# Altium schematic sheet P06_STM32MP1_PART_C_USB.SchDoc(P06_STM32MP1_PART_C_USB)
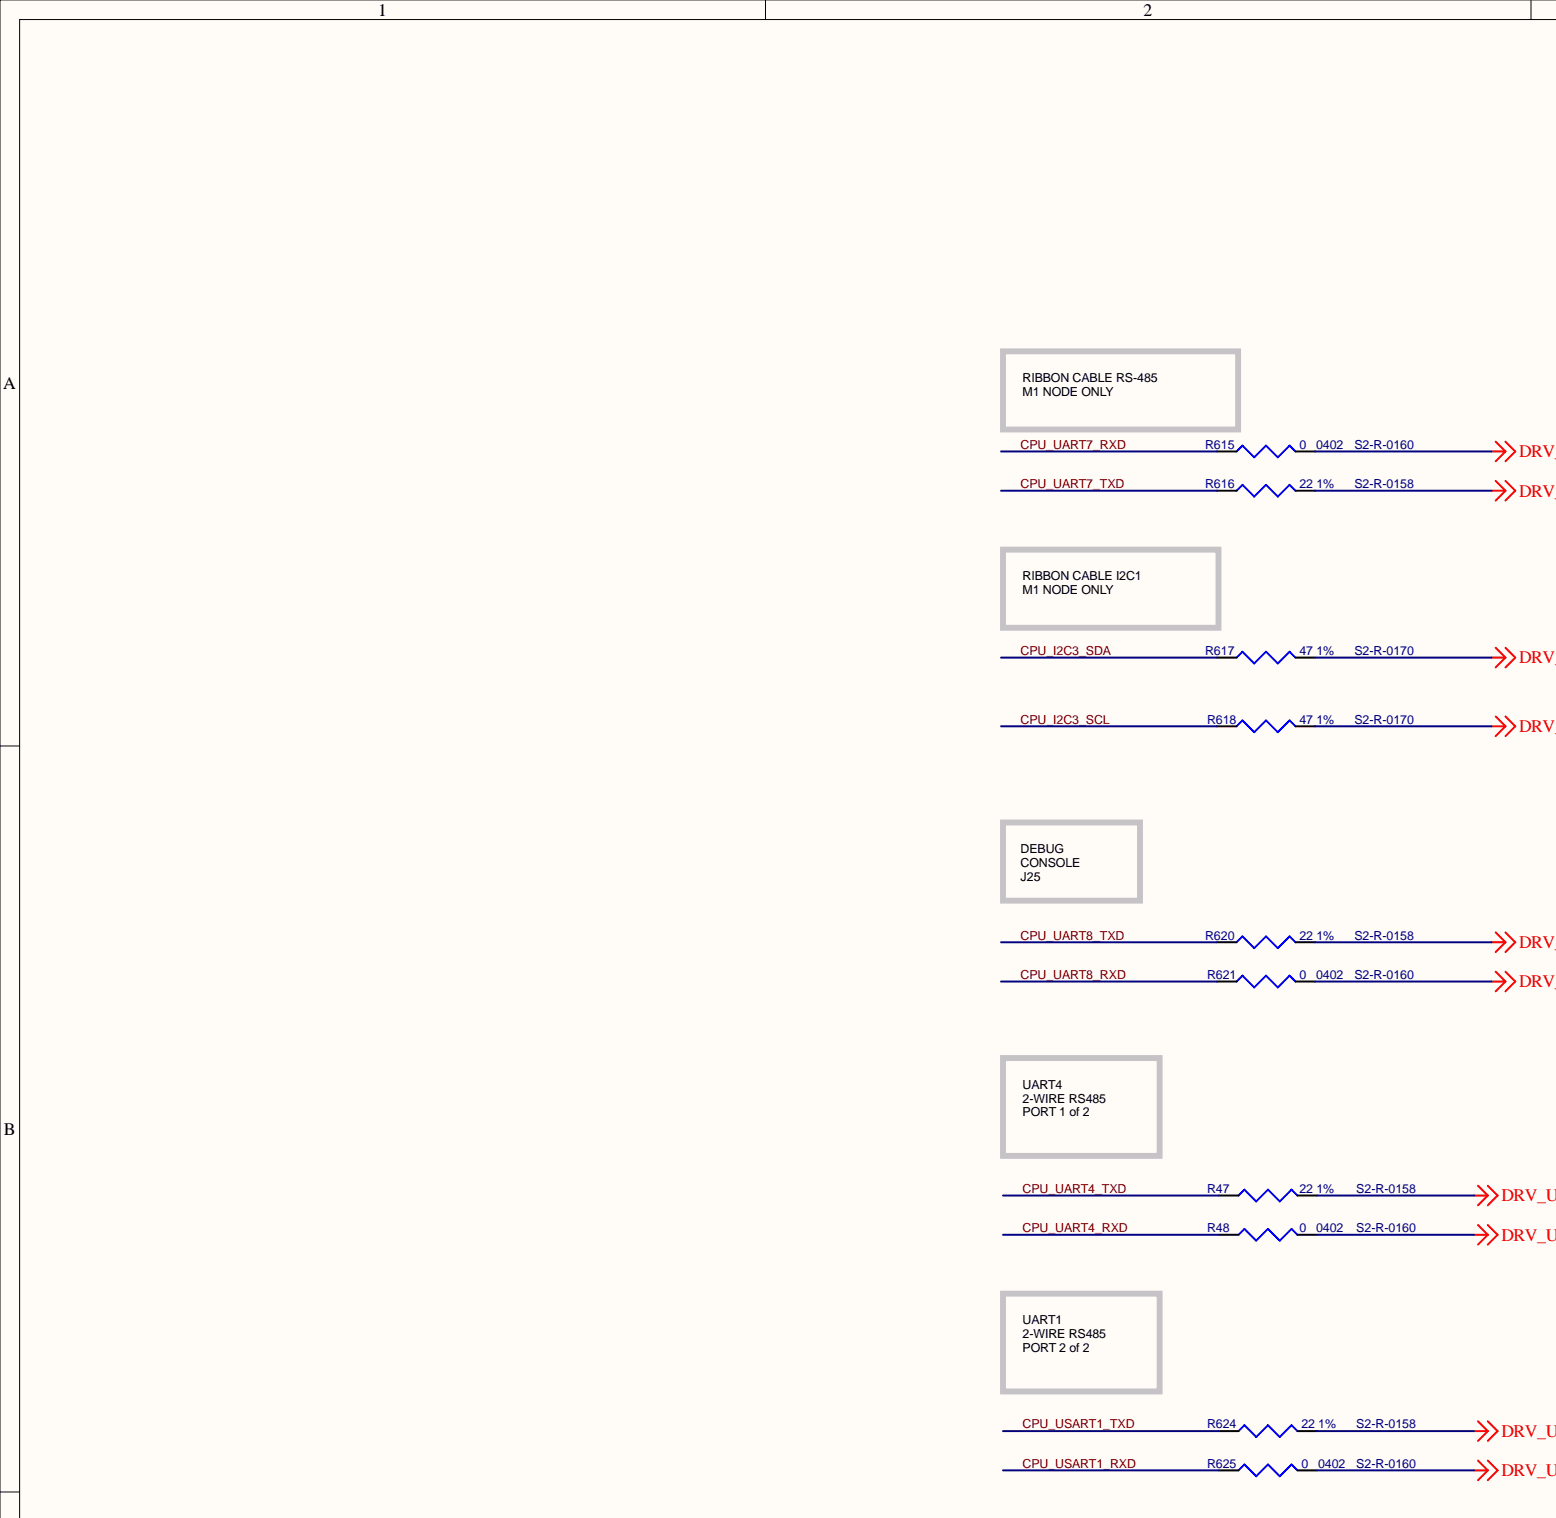
[diagram p0: P06_STM32MP1_PART_C_USB.SchDoc, top left]
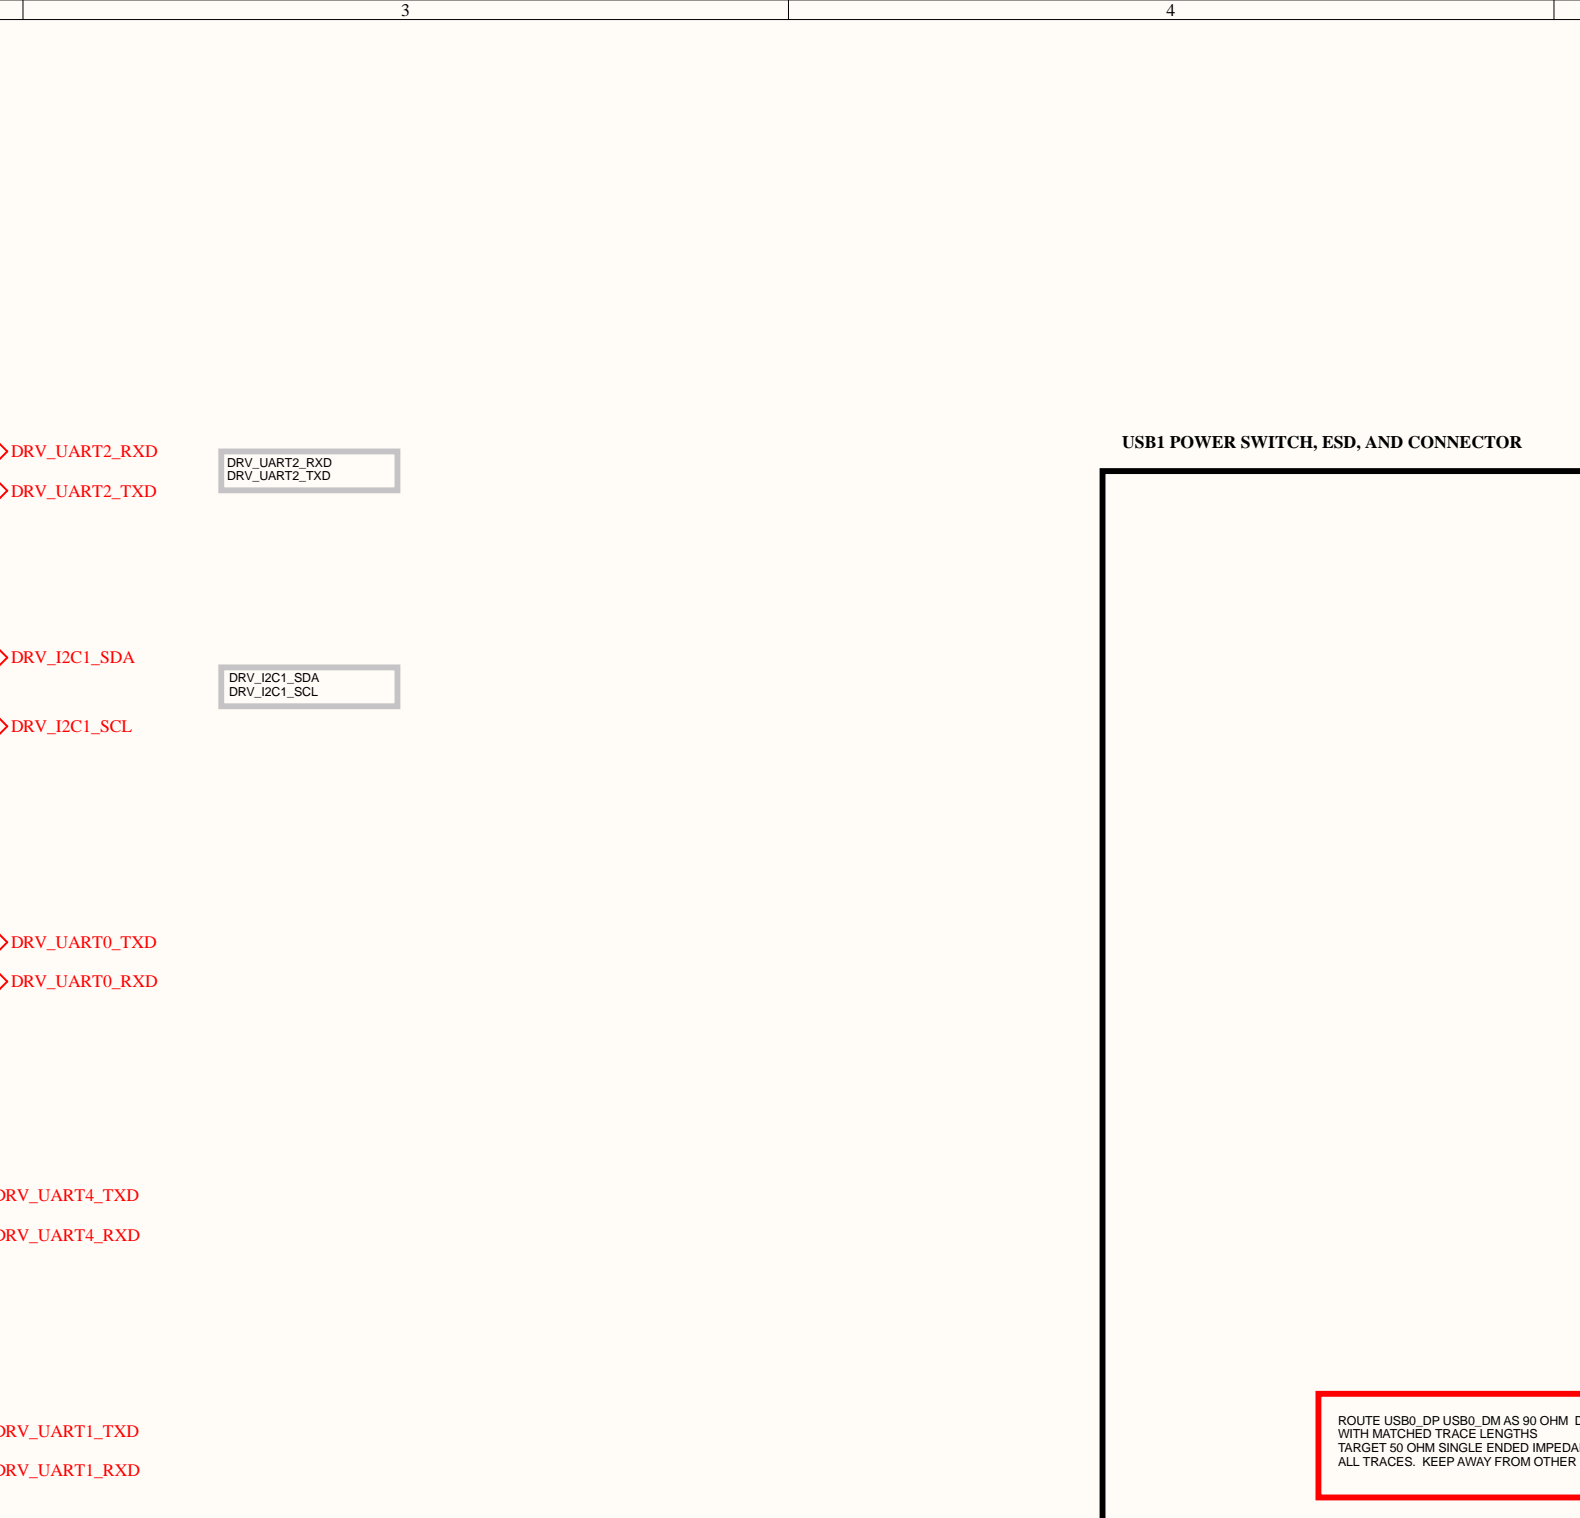
[diagram p1: P06_STM32MP1_PART_C_USB.SchDoc, top centre]
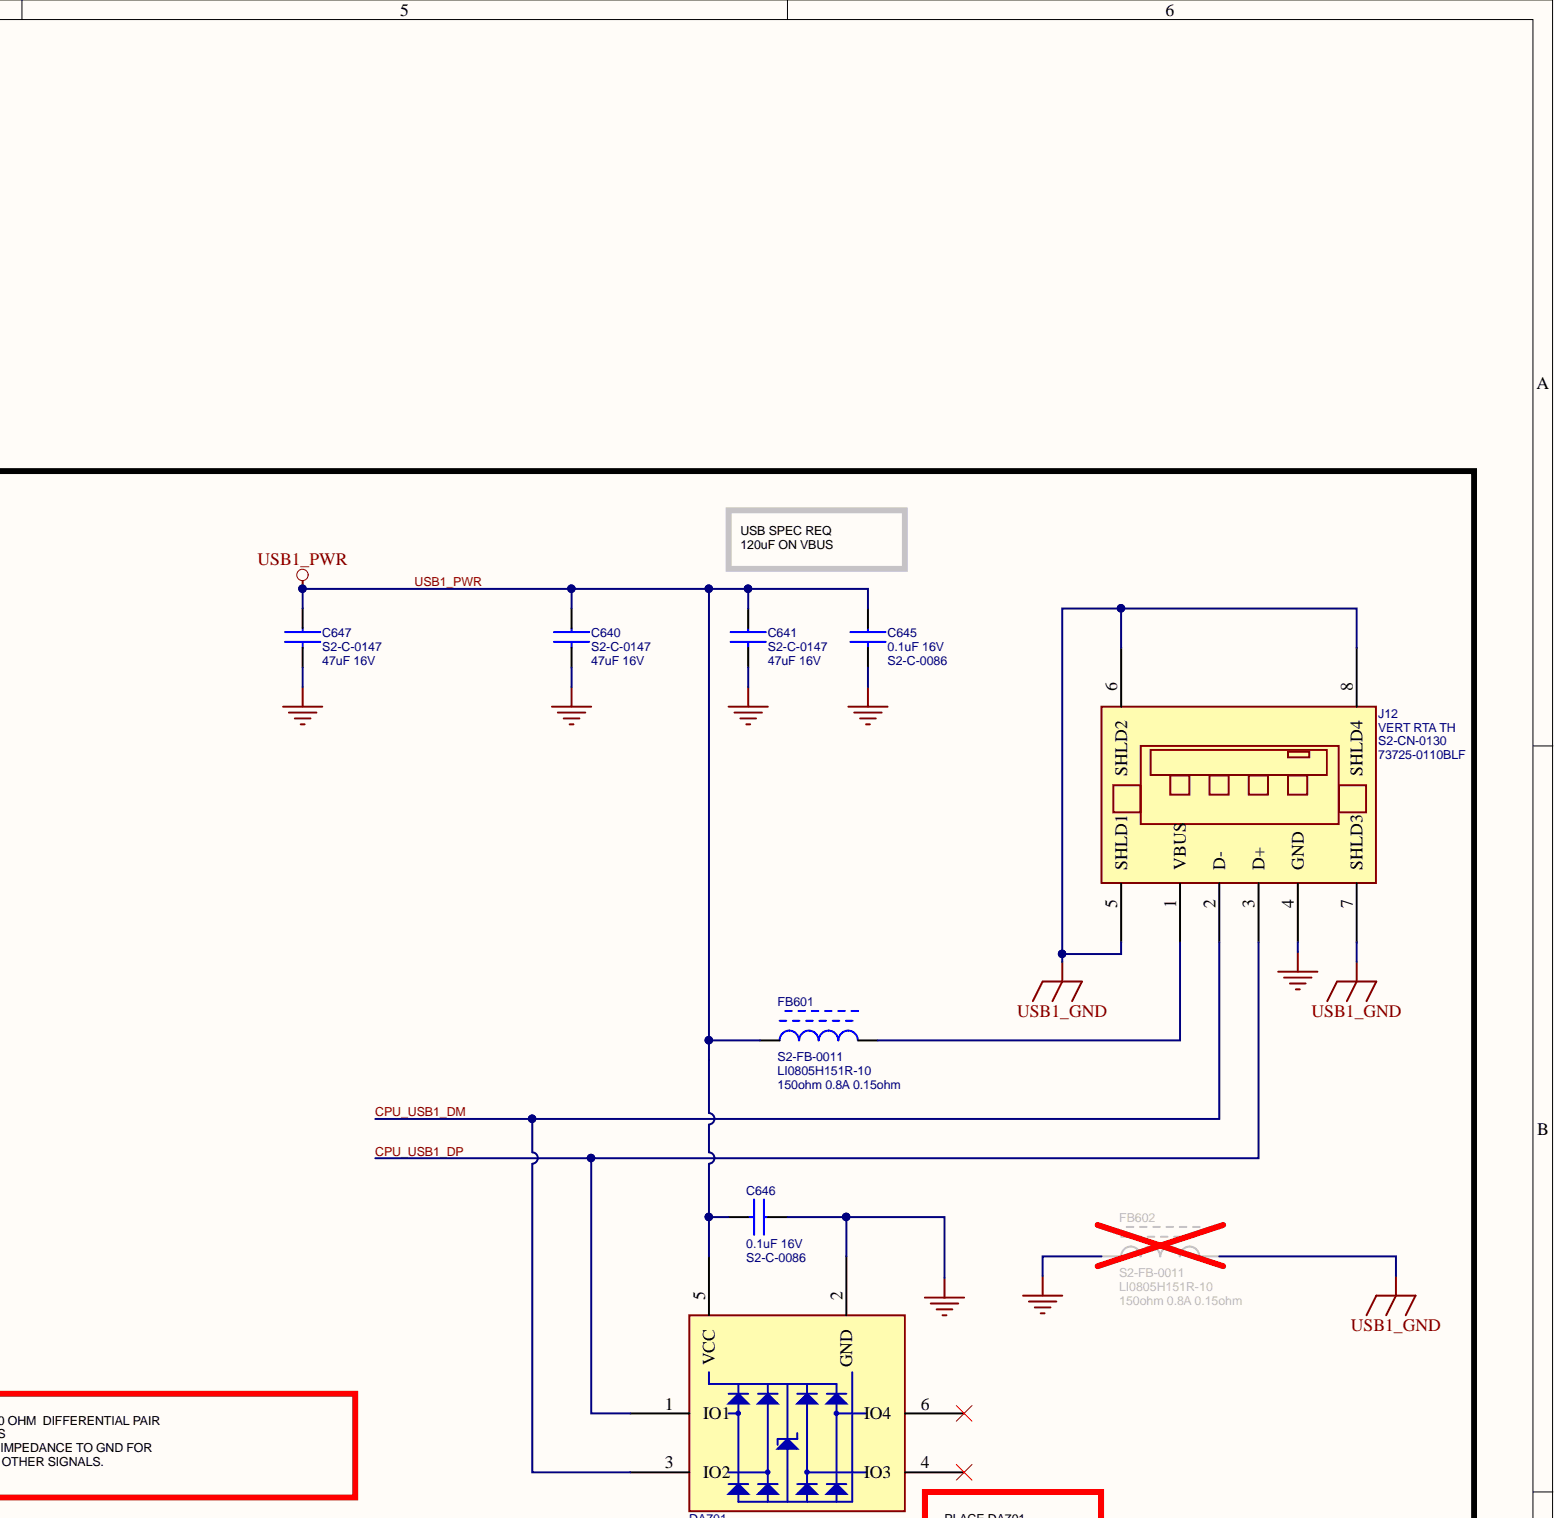
[diagram p2: P06_STM32MP1_PART_C_USB.SchDoc, top right]
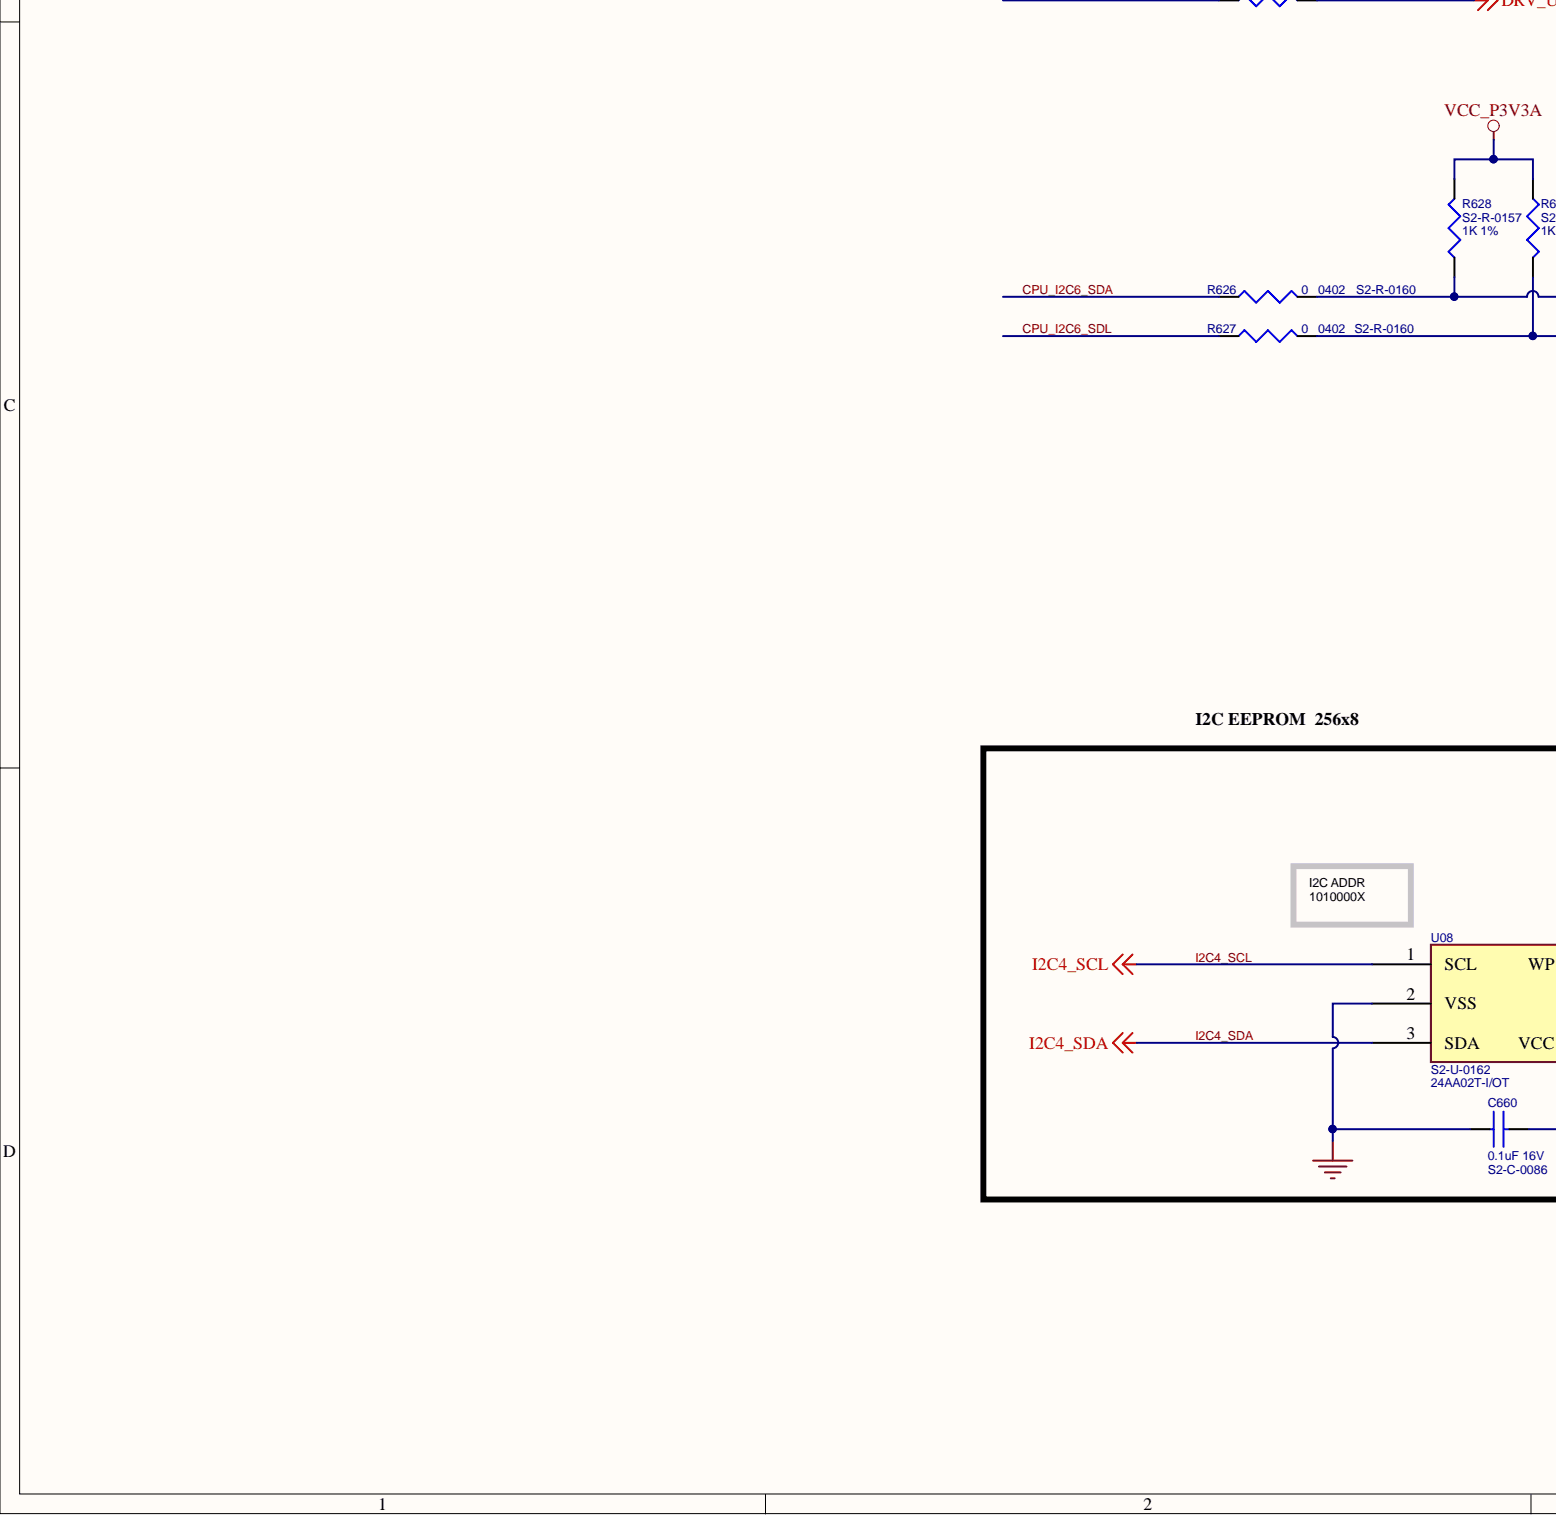
[diagram p3: P06_STM32MP1_PART_C_USB.SchDoc, bottom left]
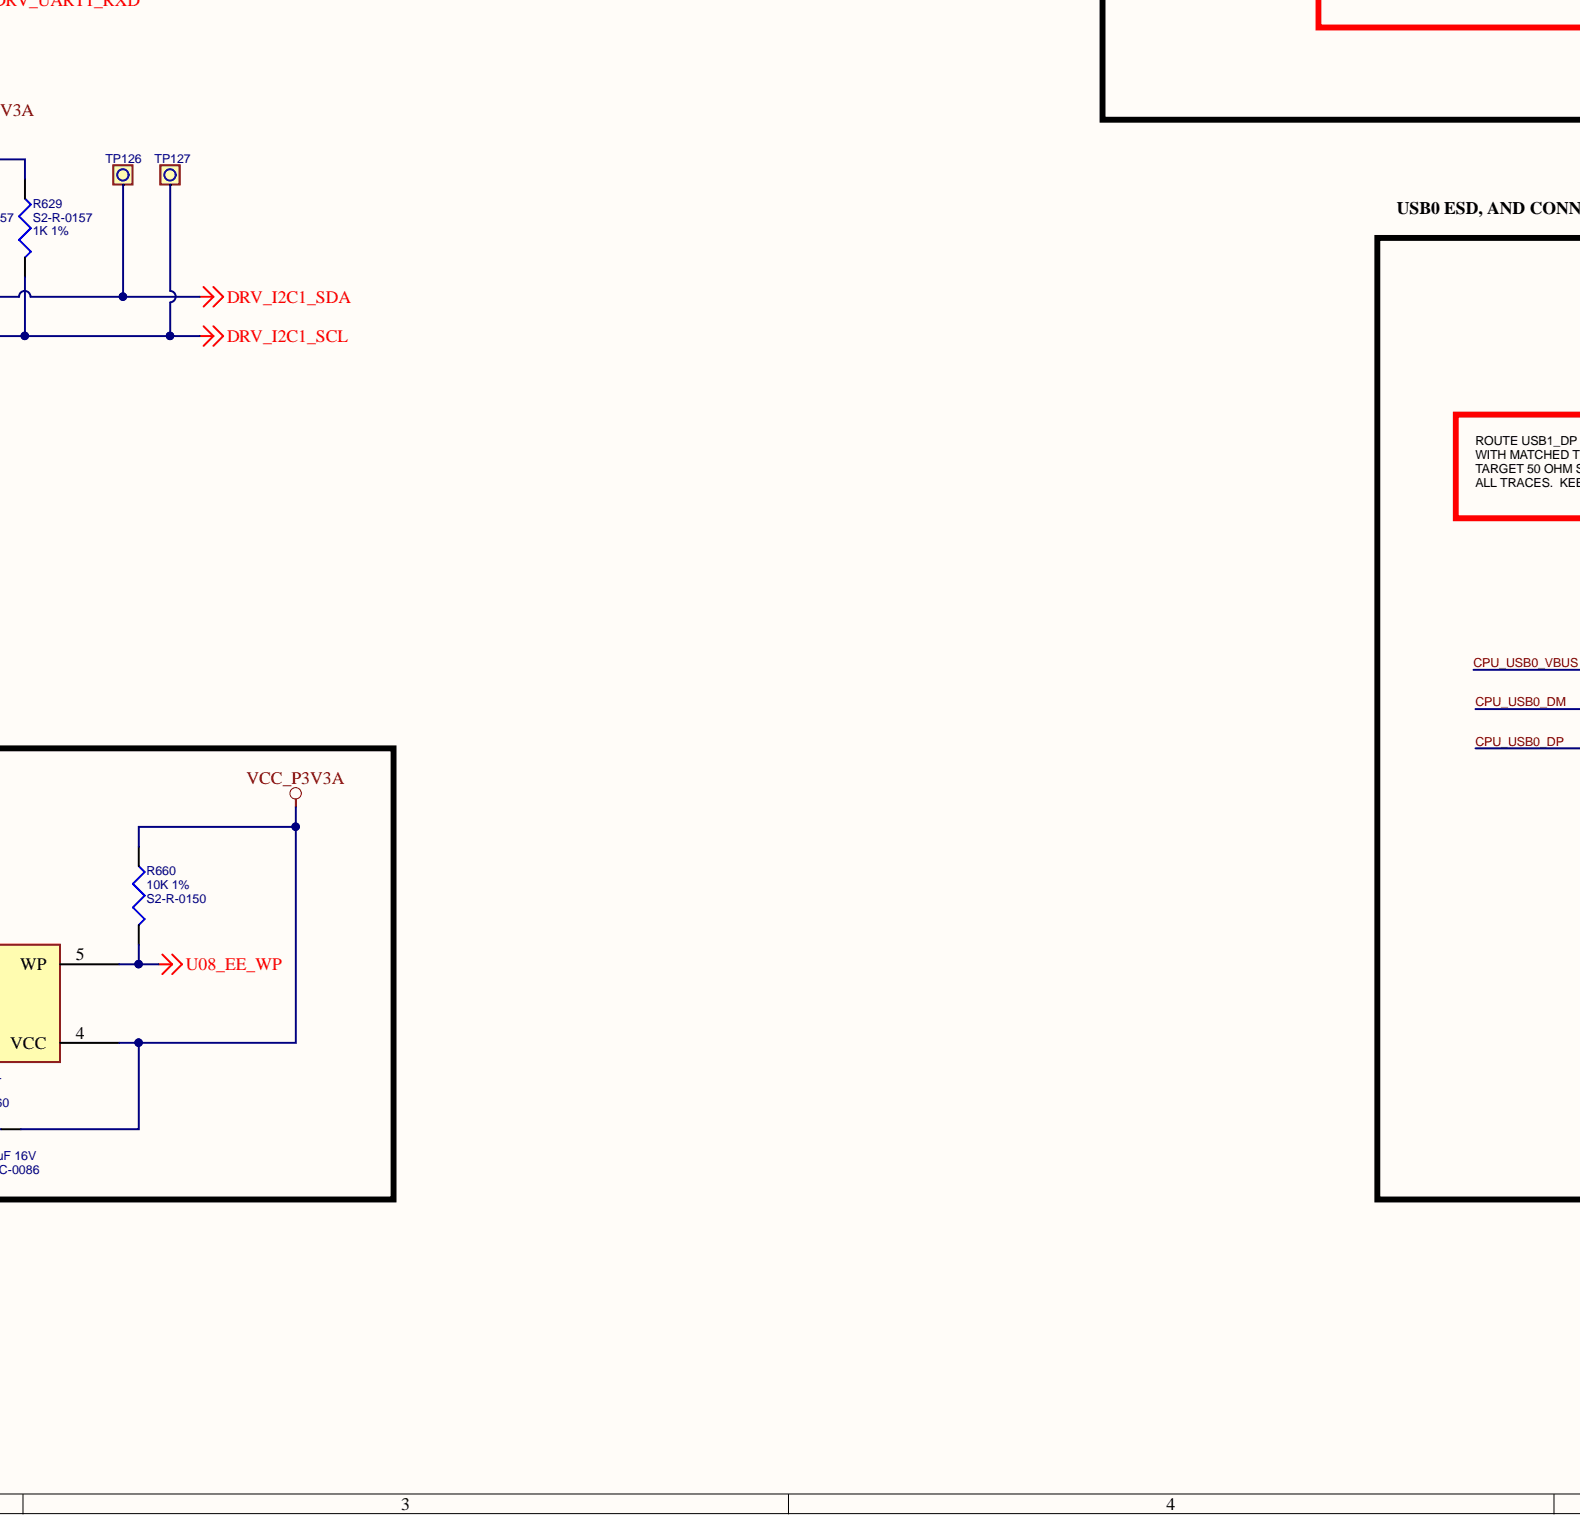
[diagram p4: P06_STM32MP1_PART_C_USB.SchDoc, bottom centre]
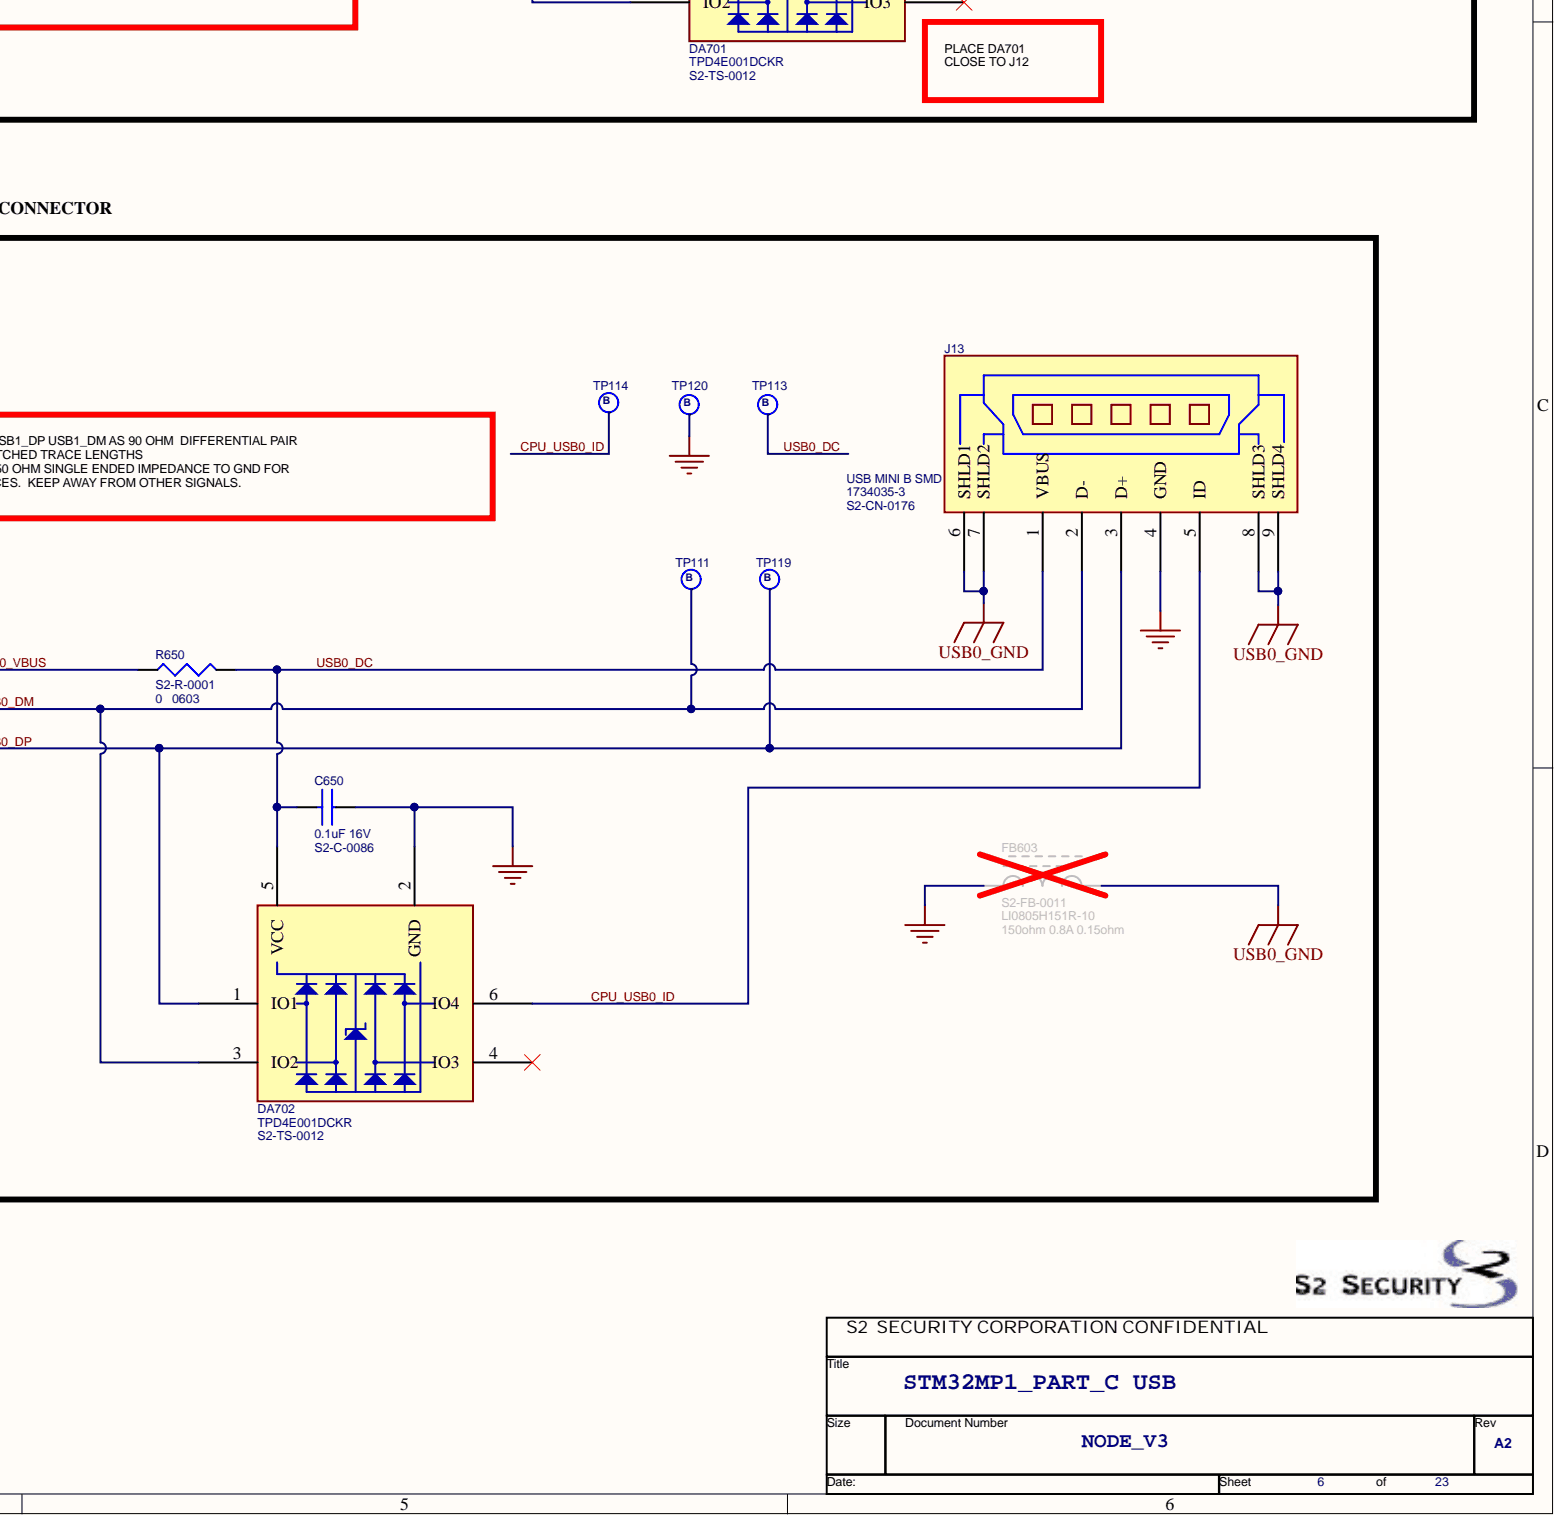
[diagram p5: P06_STM32MP1_PART_C_USB.SchDoc, bottom right]

## Parts
C640  S2_PN: S2-C-0147  DESC: 47uF 16V  [CAPC3216X180N]  (hidden comment: C_47U_16V_1206)
C641  S2_PN: S2-C-0147  DESC: 47uF 16V  [CAPC3216X180N]  (hidden comment: C_47U_16V_1206)
C645  S2_PN: S2-C-0086  DESC: 0.1uF 16V  [CAPC1005X55N]  (hidden comment: C_0U1_16V_0402)
C646  DESC: 0.1uF 16V  [CAPC1005X55N]  (hidden comment: C_0U1_16V_0402)
C647  S2_PN: S2-C-0147  DESC: 47uF 16V  [CAPC3216X180N]  (hidden comment: C_47U_16V_1206)
C650  DESC: 0.1uF 16V  [CAPC1005X55N]  (hidden comment: C_0U1_16V_0402)
C660  S2_PN: S2-C-0086  DESC: 0.1uF 16V  [CAPC1005X55N]  (hidden comment: C_0U1_16V_0402)
DA701  S2_PN: S2-TS-0012  DESC: TPD4E001DCKR  [SOIC65Px210X110P-6N]  (hidden comment: DA_TPD4E001_ESD_QUAD)
DA702  S2_PN: S2-TS-0012  DESC: TPD4E001DCKR  [SOIC65Px210X110P-6N]  (hidden comment: DA_TPD4E001_ESD_QUAD)
FB601  S2_PN: S2-FB-0011  DESC: LI0805H151R-10  ATTR2: 150ohm 0.8A 0.15ohm  [FB0805]  (hidden comment: FB_0A8_150OHM_0805_DC_150mOHM)
FB602  S2_PN: S2-FB-0011  DESC: LI0805H151R-10  ATTR2: 150ohm 0.8A 0.15ohm  [FB0805]  (hidden comment: FB_0A8_150OHM_0805_DC_150mOHM)
FB603  S2_PN: S2-FB-0011  DESC: LI0805H151R-10  ATTR2: 150ohm 0.8A 0.15ohm  [FB0805]  (hidden comment: FB_0A8_150OHM_0805_DC_150mOHM)
J12  S2_PN: S2-CN-0130  DESC: 73725-0110BLF  ATTR2: VERT RTA TH  [CONN_73725-0110BLF]  (hidden comment: CN_USB_TYPE_A_RTA_VERT_TH)
J13  S2_PN: S2-CN-0176  PKG: 1734035-3  [CONN_UX60SC-MB-5ST]  (hidden comment: CN_USB2.0_MINI_B_RCPT_RTA)
R47  S2_PN: S2-R-0158  DESC: 22 1%  [RESC1005X40N]  (hidden comment: R_22_0402)
R48  S2_PN: S2-R-0160  DESC: 0   0402  [RESC1005X40N]  (hidden comment: R_0_0402)
R615  S2_PN: S2-R-0160  DESC: 0   0402  [RESC1005X40N]  (hidden comment: R_0_0402)
R616  S2_PN: S2-R-0158  DESC: 22 1%  [RESC1005X40N]  (hidden comment: R_22_0402)
R617  S2_PN: S2-R-0170  DESC: 47 1%  [RESC1005X40N]  (hidden comment: R_47_0402)
R618  S2_PN: S2-R-0170  DESC: 47 1%  [RESC1005X40N]  (hidden comment: R_47_0402)
R620  S2_PN: S2-R-0158  DESC: 22 1%  [RESC1005X40N]  (hidden comment: R_22_0402)
R621  S2_PN: S2-R-0160  DESC: 0   0402  [RESC1005X40N]  (hidden comment: R_0_0402)
R624  S2_PN: S2-R-0158  DESC: 22 1%  [RESC1005X40N]  (hidden comment: R_22_0402)
R625  S2_PN: S2-R-0160  DESC: 0   0402  [RESC1005X40N]  (hidden comment: R_0_0402)
R626  S2_PN: S2-R-0160  DESC: 0   0402  [RESC1005X40N]  (hidden comment: R_0_0402)
R627  S2_PN: S2-R-0160  DESC: 0   0402  [RESC1005X40N]  (hidden comment: R_0_0402)
R628  S2_PN: S2-R-0157  DESC: 1K 1%  [RESC1005X40N]  (hidden comment: R_1K_0402)
R629  S2_PN: S2-R-0157  DESC: 1K 1%  [RESC1005X40N]  (hidden comment: R_1K_0402)
R650  S2_PN: S2-R-0001  DESC: 0   0603  [RESC1608X55N]  (hidden comment: R_0_0603)
R660  S2_PN: S2-R-0150  DESC: 10K 1%  [RESC1005X40N]  (hidden comment: R_10K_0402)
TP111  TP_SMT_TEST_POINT_BOTTOM  [TP35_SMT]  (value not drawn)
TP113  TP_SMT_TEST_POINT_BOTTOM  [TP35_SMT]  (value not drawn)
TP114  TP_SMT_TEST_POINT_BOTTOM  [TP35_SMT]  (value not drawn)
TP119  TP_SMT_TEST_POINT_BOTTOM  [TP35_SMT]  (value not drawn)
TP120  TP_SMT_TEST_POINT_BOTTOM  [TP35_SMT]  (value not drawn)
TP126  TP_TH_TEST_POINT_SQUARE  [TEST-PT-TH-SQ]  (value not drawn)
TP127  TP_TH_TEST_POINT_SQUARE  [TEST-PT-TH-SQ]  (value not drawn)
U08  S2_PN: S2-U-0162  [SOT95P280X145-5N]  (hidden comment: U_EEPROM_SOT-23-5)

## Nets
DRV_I2C1_SCL: R618.2 R627.2 R629.2 TP127.1
DRV_I2C1_SDA: R617.2 R626.2 R628.2 TP126.1
DRV_UART0_RXD: R621.2
DRV_UART0_TXD: R620.2
DRV_UART1_RXD: R625.2
DRV_UART1_TXD: R624.2
DRV_UART2_RXD: R615.2
DRV_UART2_TXD: R616.2
DRV_UART4_RXD: R48.2
DRV_UART4_TXD: R47.2
GND: C640.2 C641.2 C645.2 C646.1 C647.2 C650.1 C660.1 DA701.2(GND) DA702.2(GND) FB602.1 FB603.1 J12.4(GND) J13.4(GND) TP120.1 U08.2(VSS)
U08_EE_WP: R660.2 U08.5(WP)
USB0_GND: FB603.2 J13.6(SHLD1) J13.7(SHLD2) J13.8(SHLD3) J13.9(SHLD4)
USB1_GND: FB602.2 J12.5(SHLD1) J12.6(SHLD2) J12.7(SHLD3) J12.8(SHLD4)
VCC_P3V3A: C660.2 R628.1 R629.1 R660.1 U08.4(VCC)
CPU_I2C3_SCL: R618.1
CPU_I2C3_SDA: R617.1
CPU_I2C6_SDA: R626.1
CPU_I2C6_SDL: R627.1
CPU_UART4_RXD: R48.1
CPU_UART4_TXD: R47.1
CPU_UART7_RXD: R615.1
CPU_UART7_TXD: R616.1
CPU_UART8_RXD: R621.1
CPU_UART8_TXD: R620.1
CPU_USART1_RXD: R625.1
CPU_USART1_TXD: R624.1
CPU_USB0_DM: DA702.3(IO2) J13.2(D-) TP111.1
CPU_USB0_DP: DA702.1(IO1) J13.3(D+) TP119.1
CPU_USB0_ID: DA702.6(IO4) J13.5(ID) TP114.1
CPU_USB0_VBUS: R650.1
CPU_USB1_DM: DA701.3(IO2) J12.2(D-)
CPU_USB1_DP: DA701.1(IO1) J12.3(D+)
I2C4_SCL: U08.1(SCL)
I2C4_SDA: U08.3(SDA)
USB0_DC: C650.2 DA702.5(VCC) J13.1(VBUS) R650.2 TP113.1
USB1_PWR: C640.1 C641.1 C645.1 C646.2 C647.1 DA701.5(VCC) FB601.1
NetFB601_2: FB601.2 J12.1(VBUS)

Not connected: DA701 4(IO3) 6(IO4); DA702 4(IO3)

## Library data of the parts
C640 C641 C647 = C_47U_16V_1206: PKG: CC1206IPC; ATTR: X5R 20%; Library Name: NODE_V2_M1.SCHLIB; Component Kind: Standard
C645 C660 = C_0U1_16V_0402: PKG: CC0402IPC; ATTR: X7R 10%; Library Name: NODE_V2_M1.SCHLIB; Component Kind: Standard
C646 C650 = C_0U1_16V_0402: S2_PN: S2-C-0086; PKG: CC0402IPC; ATTR: X7R 10%; Library Name: NODE_V2_M1.SCHLIB; Component Kind: Standard
DA701 DA702 = DA_TPD4E001_ESD_QUAD: PKG: SC-70-6; ATTR: ESD PROTECTION QUAD LOW VOLT LOW VAP; Library Name: NODE_V2_M1.SCHLIB; Component Kind: Standard
FB601-FB603 = FB_0A8_150OHM_0805_DC_150mOHM: PKG: CC0805IPC; ATTR: FERRITE 800MA 150 OHM 0805, 0.15 OHM DC; Component Kind: Standard
  FB601: Library Name: NODE_V2_M1.SCHLIB
  FB602 FB603: Library Name: NODE_V2.SCHLIB
J12 = CN_USB_TYPE_A_RTA_VERT_TH: PKG: USB_A_RCPT_TH_RTA_VERT; ATTR: CONN USB RCPT TYPE A VERT TH RTA; Library Name: NODE_V2_M1.SCHLIB; Component Kind: Standard
J13 = CN_USB2.0_MINI_B_RCPT_RTA: ATTR2: USB MINI B SMD; ATTR: CONN USB RCPT MINI B RTA SMD; Library Name: NODE_V2_M1.SCHLIB; DESC: 1734035-3; Component Kind: Standard
R47 R616 R620 R624 = R_22_0402: PKG: CC0402IPC; ATTR: 1/16W; Library Name: NODE_V2_M1.SCHLIB; Component Kind: Standard
R48 R615 R621 R625-R627 = R_0_0402: PKG: CC0402IPC; ATTR: 1/16W  50mohm MAX; Library Name: NODE_V2_M1.SCHLIB; Component Kind: Standard
R617 R618 = R_47_0402: PKG: CC0402IPC; ATTR: 1/16W; Library Name: NODE_V2_M1.SCHLIB; Component Kind: Standard
R628 R629 = R_1K_0402: PKG: CC0402IPC; ATTR: 1/16W; Library Name: NODE_V2_M1.SCHLIB; DNP: DNP; Component Kind: Standard
R650 = R_0_0603: PKG: CC0603IPC; ATTR: 1/10W 50mohm MAX; Library Name: NODE_V2_M1.SCHLIB; Component Kind: Standard
R660 = R_10K_0402: PKG: CC0402IPC; ATTR: 1/16W; Library Name: NODE_V2_M1.SCHLIB; Component Kind: Standard
TP111 TP113 TP114 TP119 TP120 = TP_SMT_TEST_POINT_BOTTOM: S2_PN: S2-TP-0003; PKG: TEST-PT-TPB; DESC: TEST POINT; ATTR: SMT BOTTOM SIDE TEST POINT; Library Name: NODE_V2_M1.SCHLIB; DNP: DNP; Component Kind: Standard
TP126 TP127 = TP_TH_TEST_POINT_SQUARE: S2_PN: S2-TP-0004; PKG: TEST-PT-TH; DESC: TEST POINT; ATTR: THRU HOLE TEST POINT; Library Name: NODE_V2_M1.SCHLIB; DNP: DNP; Component Kind: Standard
U08 = U_EEPROM_SOT-23-5: DESC: 24AA02T-I/OT; ATTR: MEMORY EEPROM I2C 256X8 1.7V-5.5V SOT-23-5; PKG: SOT-23-5; Library Name: NODE_V2_M1.SCHLIB; Component Kind: Standard
